# Altium schematic sheet Sheet2.SchDoc
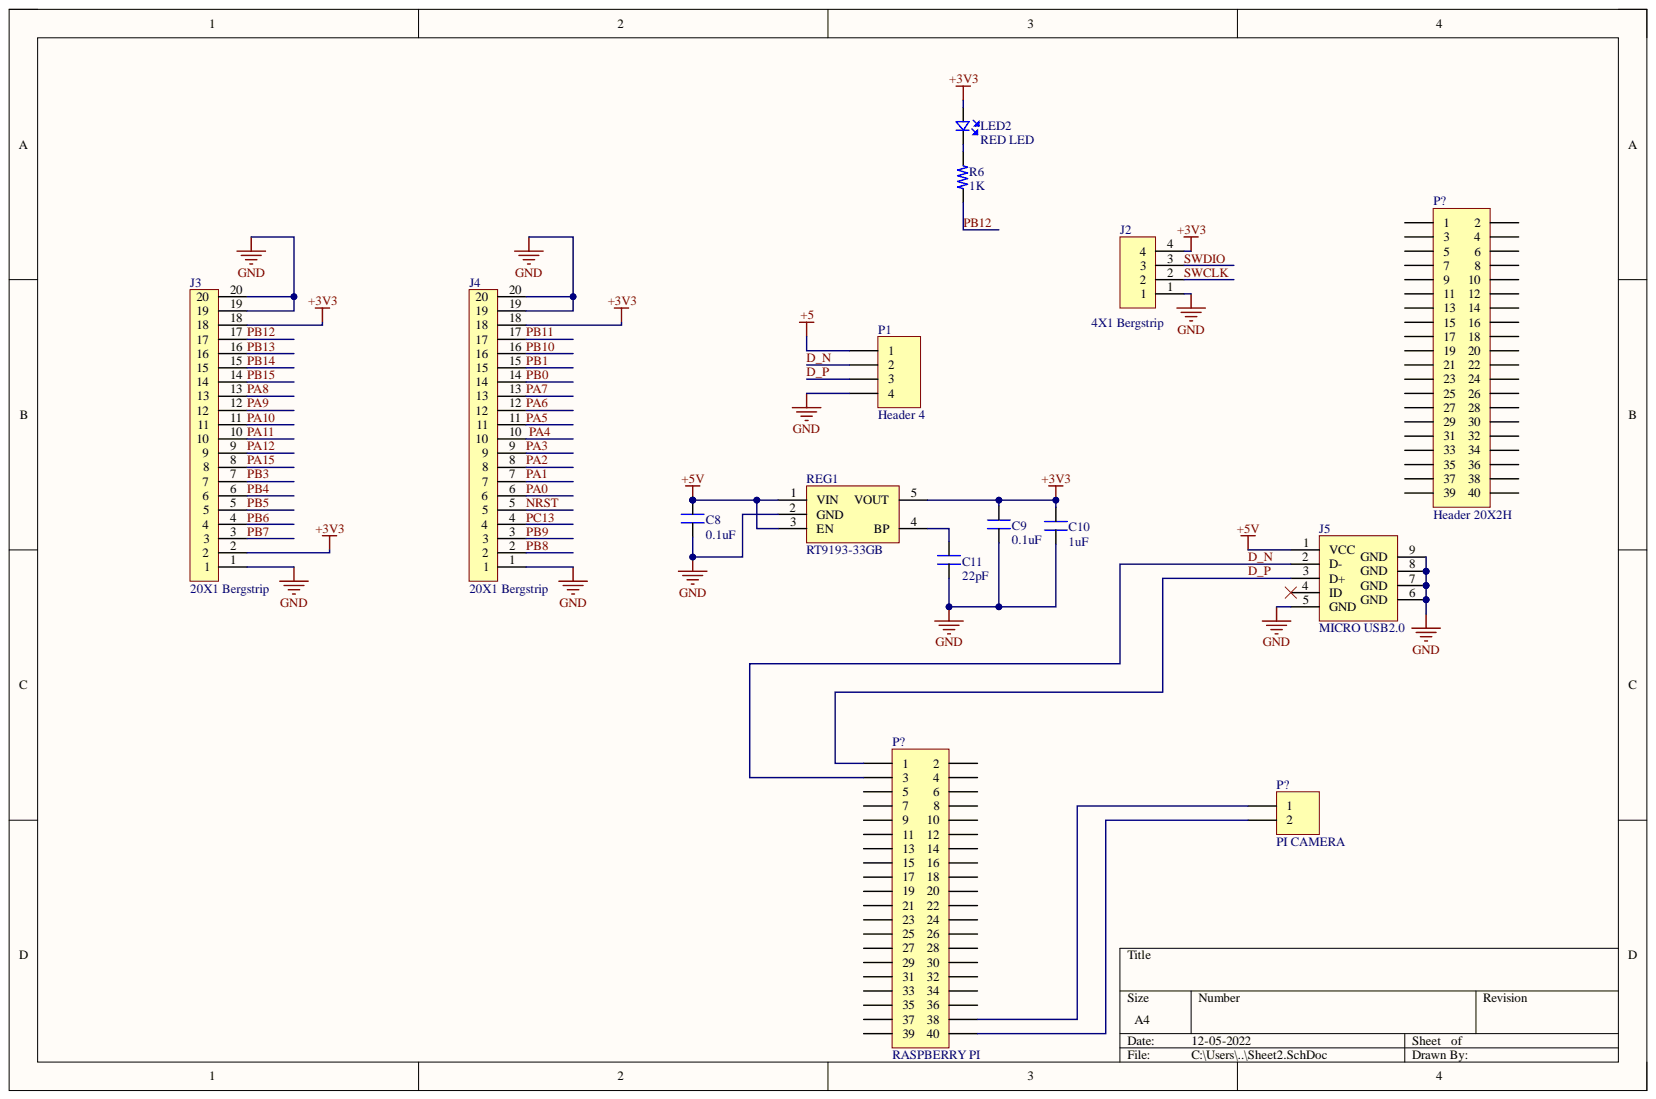
[diagram p0: Sheet2.SchDoc]

## Parts
C8  0.1uF  [CAP_0805]
C9  0.1uF  [CAP_0805]
C10  1uF  [CAP_0805]
C11  22pF  [CAP_0805]
J2  4X1 Bergstrip  [CON4_100_40D72_TH_ST_MALE]
J3  20X1 Bergstrip  [CON20_100_40D72_TH_ST]
J4  20X1 Bergstrip  [CON20_100_40D72_TH_ST]
J5  MICRO USB2.0  [CON_10118192-0001LF_SMD]
LED2  RED LED  [LED_0805]
P1  Header 4  [HDR1X4]
P?  RASPBERRY PI  [HDR2X20]  (value not drawn)
P?  Header 20X2H  [HDR2X20H]
P?  PI CAMERA  [HDR1X2]  (value not drawn)
R6  1K  [RES_0805]
REG1  RT9193-33GB  [SOT23-5]

## Nets
+3V3: C9.2 C10.2 J2.4 J3.2 J3.18 J4.18 LED2.A REG1.5
+5: P1.1
+5V: C8.2 J5.1 REG1.1 REG1.3
GND: C8.1 C9.1 C10.1 C11.1 J2.1 J3.1 J3.19 J3.20 J4.1 J4.19 J4.20 J5.5 J5.6 J5.7 J5.8 J5.9 P1.4 REG1.2
D_N: J5.2 P1.2 P?.3
D_P: J5.3 P1.3 P?.1
NRST: J4.5
PA0: J4.6
PA1: J4.7
PA2: J4.8
PA3: J4.9
PA4: J4.10
PA5: J4.11
PA6: J4.12
PA7: J4.13
PA8: J3.13
PA9: J3.12
PA10: J3.11
PA11: J3.10
PA12: J3.9
PA15: J3.8
PB0: J4.14
PB1: J4.15
PB3: J3.7
PB4: J3.6
PB5: J3.5
PB6: J3.4
PB7: J3.3
PB8: J4.2
PB9: J4.3
PB10: J4.16
PB11: J4.17
PB12: J3.17 R6.1
PB13: J3.16
PB14: J3.15
PB15: J3.14
PC13: J4.4
SWCLK: J2.2
SWDIO: J2.3
NetC11_2: C11.2 REG1.4
NetLED2_K: LED2.K R6.2
NetP?_1: P?.1 P?.38
NetP?_2: P?.2 P?.40

Not connected: J5 4; P? 4 5 6 7 8 9 10 11 12 13 14 15 16 17 18 19 20 21 22 23 24 25 26 27 28 29 30 31 32 33 34 35 36 37 39

## Library data of the parts
C8 C9 = CAP_0.1uF-50V-5%-0805: 0.1µF ±5% 50V Ceramic Capacitor X7R 0805 (2012 Metric); Manufacturer: KEMET; Manufacturing Part Number: C0805C104J5RACTU; Case/Package: 0805 (2012 Metric); Component Kind: Standard; Library Name: STM_SCH_LIB.SchLib; Supplier-1: Digikey; Supplier Part Number-1: 399-1171-1-ND
C10 = CAP_1uF-50V-5%-0805: 1µF ±5% 50V Ceramic Capacitor X7R 0805 (2012 Metric); Manufacturer: Yageo; Manufacturing Part Number: CC0805JKX7R9BB105; Case/Package: 0805 (2012 Metric); Component Kind: Standard; Library Name: STM_SCH_LIB.SchLib; Supplier-1: Digikey; Supplier Part Number-1: 311-3498-1-ND
C11 = CAP_22pF-50V-5%-0805: 22pF ±5% 50V Ceramic Capacitor C0G, NP0 0805 (2012 Metric); Manufacturer: KEMET; Manufacturer Part Number: C0805C220J5GACTU; Case/Package: 0805; Component Kind: Standard; Library Name: STM_SCH_LIB.SchLib; Supplier-1: Digikey; Supplier Part Number-1: 399-1113-1-ND
J2 = CON-BS-PLG_4X1-100-TH-ST: 4 pin, 1 row, 2.54mm pitch bergstrip; Manufacturer: NA; Case/Package: TH; Component Kind: Standard; Library Name: STM_SCH_LIB.SchLib; Manufacturing Part Number: NA; Supplier-1: NA; Supplier Part Number-1: NA
J3 J4 = CON-BS-PLG_20X1-100-TH-ST: 20 pin, 1 row, 2.54mm pitch bergstrip; Manufacturer: NA; Case/Package: TH; Component Kind: Standard; Library Name: STM_SCH_LIB.SchLib; Manufacturing Part Number: NA; Supplier-1: NA; Supplier Part Number-1: NA
J5 = CON-USB_10118192-0002LF-SMD: USB - micro B USB 2.0 Receptacle Connector 5 Position Surface Mount, Right Angle; Manufacturer: Amphenol ICC (FCI); Case/Package: SMD; Component Kind: Standard; Library Name: STM_SCH_LIB.SchLib; Manufacturing Part Number: 10118192-0002LF; Supplier-1: Digikey; Supplier Part Number-1: 609-5379-1-ND
LED2 = LED-RED_10mA-0805: Red 621nm LED Indication - Discrete 2V 0805 (2012 Metric); Manufacturer: Lite-On Inc.; Manufacturer Part Number: LTST-C170EKT; Case/ Package: 0805; Component Kind: Standard; Library Name: STM_SCH_LIB.SchLib; Supplier-1: Digikey; Supplier Part Number-1: 160-1178-1-ND
P1 = Header 4: Header, 4-Pin; Component Kind: Standard; LatestRevisionDate: 17-Jul-2002; LatestRevisionNote: Re-released for DXP Platform.; Library Name: Miscellaneous Connectors.IntLib; Signal Integrity: Connector; Publisher: Altium Limited
P? = Header 20X2: Header, 20-Pin, Dual row; Component Kind: Standard; LatestRevisionDate: 17-Jul-2002; LatestRevisionNote: Re-released for DXP Platform.; Library Name: Miscellaneous Connectors.IntLib; Signal Integrity: Connector; Publisher: Altium Limited
P? = Header 20X2H: Header, 20-Pin, Dual row, Right Angle; Component Kind: Standard; LatestRevisionDate: 17-Jul-2002; LatestRevisionNote: Re-released for DXP Platform.; Library Name: Miscellaneous Connectors.IntLib; Signal Integrity: Connector; Publisher: Altium Limited
P? = Header 2: Header, 2-Pin; Component Kind: Standard; LatestRevisionDate: 17-Jul-2002; LatestRevisionNote: Re-released for DXP Platform.; Library Name: Miscellaneous Connectors.IntLib; Signal Integrity: Connector; Publisher: Altium Limited
R6 = RES_1K-0.125W-1%-0805: 1 kOhms ±1% 0.125W, 1/8W Chip Resistor 0805 (2012 Metric) Moisture Resistant Thick Film; Manufacturer: 垈㎵á; Manufacturer Part Number: RC0805FR-071KL; Case/Package: 0805; Component Kind: Standard; Library Name: STM_SCH_LIB.SchLib; Supplier-1: Digikey; Supplier Part Number-1: 311-1.00KCRCT-ND
REG1 = REG_3.3V-5.5V-0.3A-SOT-23-5: Linear Voltage Regulator IC 1 Output 300mA SOT-23-5; Manufacturer: Richtek USA Inc.; Case/ Package: SOT-23-5; Component Kind: Standard; Library Name: STM_SCH_LIB.SchLib; Manufacturer Part Number: RT9193-33GB; Supplier-1: Digikey; Supplier Part Number-1: 1028-1014-1-ND
